# Altium schematic sheet PRi-USB-ETH-CON.SchDoc(PRi-USB-ETH-CON)
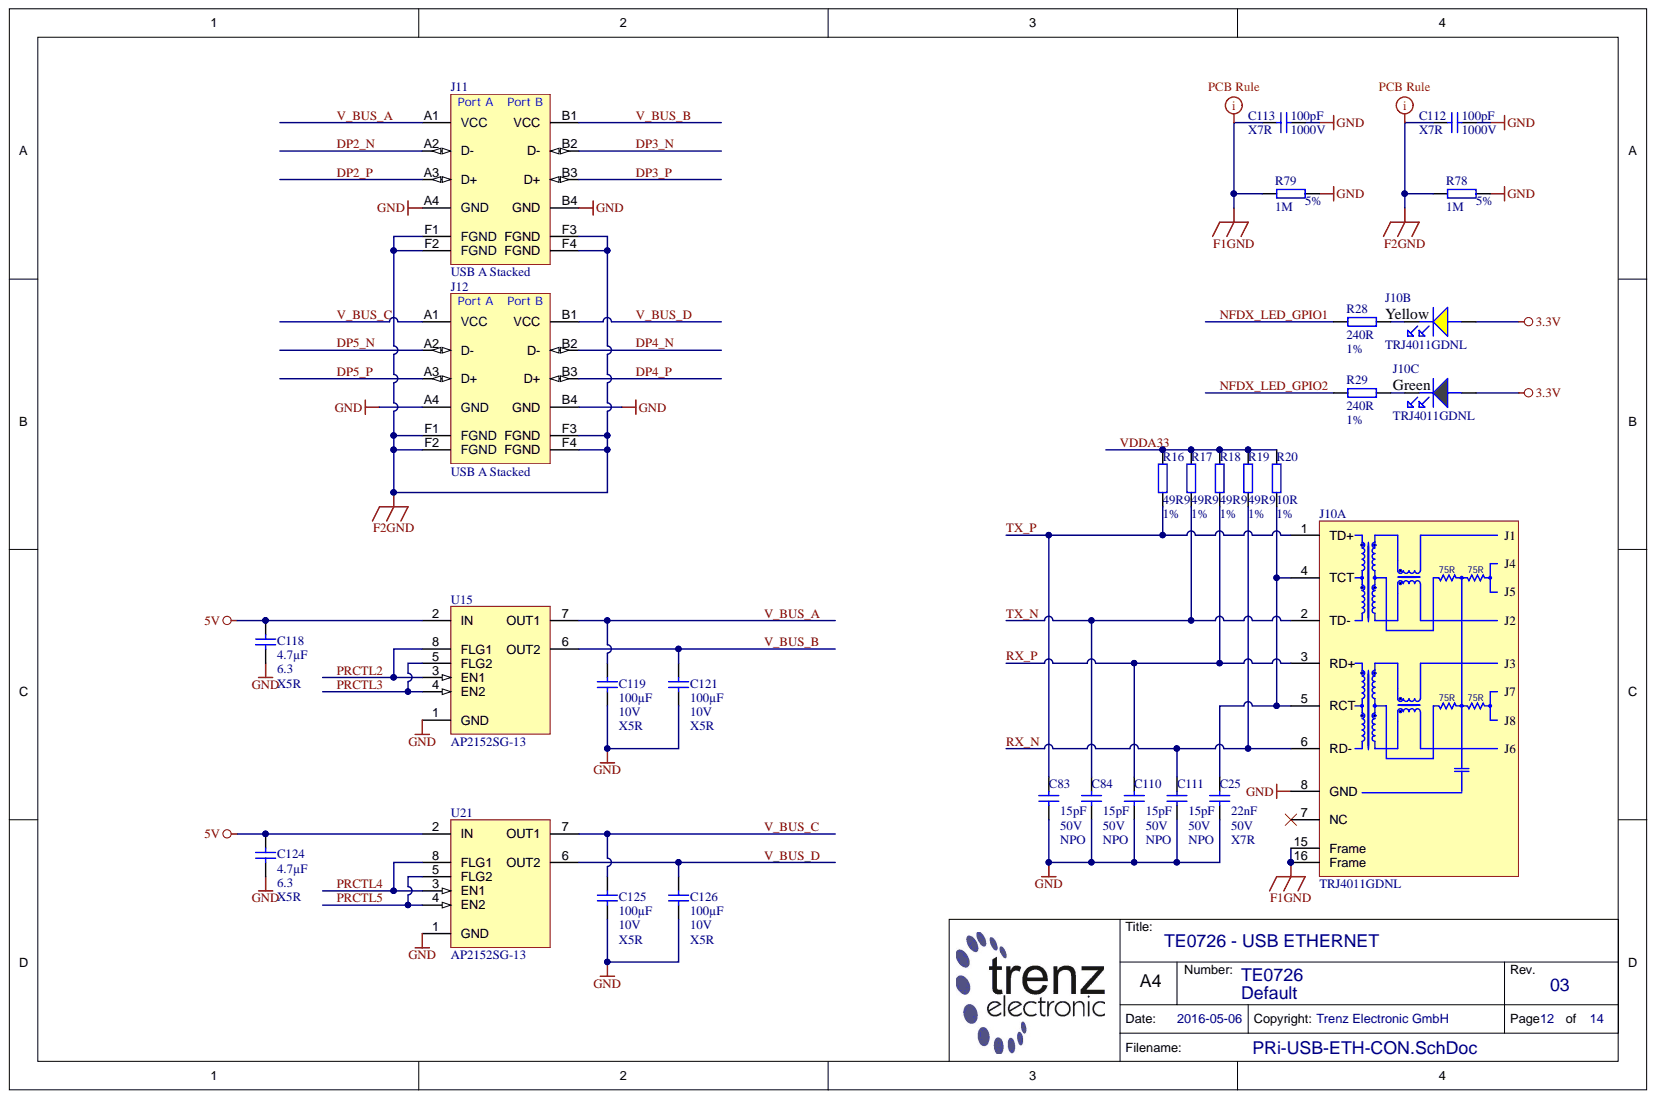
[diagram p0: PRi-USB-ETH-CON.SchDoc]

## Parts
C25  22nF  Technology: X7R  Voltage: 50V  [CAPC1005X55N]
C83  15pF  Technology: NPO  Voltage: 50V  [CAPC1005X55N]
C84  15pF  Technology: NPO  Voltage: 50V  [CAPC1005X55N]
C110  15pF  Technology: NPO  Voltage: 50V  [CAPC1005X55N]
C111  15pF  Technology: NPO  Voltage: 50V  [CAPC1005X55N]
C112  100pF  Technology: 1000V  Voltage: X7R  [CAPC3216X180N]
C113  100pF  Technology: 1000V  Voltage: X7R  [CAPC3216X180N]
C118  4.7µF  Technology: X5R  Voltage: 6.3  [CAPC1005X60N]
C119  100µF  Technology: X5R  Voltage: 10V  [CAPC3216X180N]
C121  100µF  Technology: X5R  Voltage: 10V  [CAPC3216X180N]
C124  4.7µF  Technology: X5R  Voltage: 6.3  [CAPC1005X60N]
C125  100µF  Technology: X5R  Voltage: 10V  [CAPC3216X180N]
C126  100µF  Technology: X5R  Voltage: 10V  [CAPC3216X180N]
J10  TRJ4011GDNL  [7499011222A]  (parts A,B,C)
J11  USB A Stacked  [USB A Stacked]
J12  USB A Stacked  [USB A Stacked]
R16  49R9  Tolerance: 1%  [RESC1005X40N]
R17  49R9  Tolerance: 1%  [RESC1005X40N]
R18  49R9  Tolerance: 1%  [RESC1005X40N]
R19  49R9  Tolerance: 1%  [RESC1005X40N]
R20  10R  Tolerance: 1%  [RESC1005X40N]
R28  240R  Tolerance: 1%  [RESC1005X40N]
R29  240R  Tolerance: 1%  [RESC1005X40N]
R78  1M  Tolerance: 5%  [RESC3216X65N]
R79  1M  Tolerance: 5%  [RESC3216X65N]
U15  AP2152SG-13  [SOIC127P600X175-8N]
U21  AP2152SG-13  [SOIC127P600X175-8N]

## Nets
3.3V: J10.9 J10.11
5V: C118.1 C124.1 U15.2(IN) U21.2(IN)
F1GND: C113.1 J10.15(Frame) J10.16(Frame) R79.1
F2GND: C112.1 J11.F1(FGND) J11.F2(FGND) J11.F3(FGND) J11.F4(FGND) J12.F1(FGND) J12.F2(FGND) J12.F3(FGND) J12.F4(FGND) R78.1
GND: C25.2 C83.2 C84.2 C110.2 C111.2 C112.2 C113.2 C118.2 C119.2 C121.2 C124.2 C125.2 C126.2 J10.8(GND) J11.A4(GND) J11.B4(GND) J12.A4(GND) J12.B4(GND) R78.2 R79.2 U15.1(GND) U21.1(GND)
DP2_N: J11.A2(D-)
DP2_P: J11.A3(D+)
DP3_N: J11.B2(D-)
DP3_P: J11.B3(D+)
DP4_N: J12.B2(D-)
DP4_P: J12.B3(D+)
DP5_N: J12.A2(D-)
DP5_P: J12.A3(D+)
NFDX_LED_GPIO1: R28.1
NFDX_LED_GPIO2: R29.1
PRCTL2: U15.3(EN1) U15.8(FLG1)
PRCTL3: U15.4(EN2) U15.5(FLG2)
PRCTL4: U21.3(EN1) U21.8(FLG1)
PRCTL5: U21.4(EN2) U21.5(FLG2)
RX_N: C111.1 J10.6(RD-) R19.2
RX_P: C110.1 J10.3(RD+) R18.2
TX_N: C84.1 J10.2(TD-) R17.2
TX_P: C83.1 J10.1(TD+) R16.2
V_BUS_A: C119.1 J11.A1(VCC) U15.7(OUT1)
V_BUS_B: C121.1 J11.B1(VCC) U15.6(OUT2)
V_BUS_C: C125.1 J12.A1(VCC) U21.7(OUT1)
V_BUS_D: C126.1 J12.B1(VCC) U21.6(OUT2)
VDDA33: R16.1 R17.1 R18.1 R19.1 R20.1
NetC25_1: C25.1 J10.4(TCT) J10.5(RCT) R20.2
NetJ10_10: J10.10 R28.2
NetJ10_12: J10.12 R29.2

Not connected: J10 7(NC)

## Library data of the parts
C25 = 23470: KON 22nF 50V X7R SMD; Database Table Name: C_1005_0402; Mixed: SMT; Preferred: Yes; Value: 22n; AN: 23470; Case: 1005 (0402); Component Kind: Standard; Library Name: TE.DbLib
C83 C84 C110 C111 = 23951: KON 15pF NPO 50V SMD; Database Table Name: C_1005_0402; Mixed: SMT; Preferred: Yes; Value: 15p; AN: 23951; Case: 1005 (0402); Component Kind: Standard; Library Name: TE.DbLib
C112 C113 = 22679: KON 100pF 1000V X7R 10%; Database Table Name: C_3216_1206; Mixed: SMT; Preferred: Yes; Value: 100p; AN: 22679; Case: 3216 (1206); Component Kind: Standard; Library Name: TE.DbLib; Tolerance: 10%
C118 C124 = 24719: KON 4.7µF 6.3V X5R SMD 20%; Database Table Name: C_1005_0402; Mixed: SMT; Preferred: Yes; Value: 4.7u; AN: 24719; Case: 1005 (0402); Component Kind: Standard; Library Name: TE.DbLib; Tolerance: 20%
C119 C121 C125 C126 = 26278: KON 100µF 10V X5R SMD 20%; Database Table Name: C_3216_1206; Mixed: SMT; Preferred: Yes; Value: 100u; AN: 26278; Case: 3216 (1206); Component Kind: Standard; Library Name: TE.DbLib; Tolerance: 20%
J10 = 27356: LAN-Transformer 10/100 BaseT; Database Table Name: CON_IO; Mixed: THT; Trenz-Stock: Yes; AN: 27356; Component Kind: Standard; Library Name: TE.DbLib; Technology: -40 to +85 °C; HelpURL: B:\ad\adlib\datasheet\TRJ4011GDNL
J11 J12 = 25217: USB A Dual Stacked 2x4; Database Table Name: CON_IO; H-ART: 61400826021; Mixed: THT; Trenz-Stock: Yes; AN: 25217; Component Kind: Standard; Library Name: TE.DbLib; HelpURL: B:\AD\adlib\datasheet\USB\USB A Stacked
R16-R19 = 23135: WID SMD 49R9 1% 0,063W; Database Table Name: R_1005_0402; Mixed: SMT; Preferred: Yes; Value: 49.9; AN: 23135; Case: 1005 (0402); Component Kind: Standard; Library Name: TE.DbLib; Technology: TK100
R20 = 22844: WID SMD 10R 1% 0,063W; Database Table Name: R_1005_0402; Mixed: SMT; Preferred: Yes; Value: 10; AN: 22844; Case: 1005 (0402); Component Kind: Standard; Library Name: TE.DbLib; Technology: TK100
R28 R29 = 23661: WID SMD 240R 1% 0,063W; Database Table Name: R_1005_0402; Mixed: SMT; Preferred: Yes; Value: 240; AN: 23661; Case: 1005 (0402); Component Kind: Standard; Library Name: TE.DbLib; Technology: TK100
R78 R79 = 22757: WID SMD 1M 5% 0,25W; Database Table Name: R_3216_1206; Mixed: SMT; Preferred: Yes; AN: 22757; Case: 3216 (1206); Component Kind: Standard; Library Name: TE.DbLib; Technology: TK100; Value: 1M
U15 U21 = 26982: Database Table Name: SWITCH; Mixed: SMT; AN: 26982; Case: SO8; Component Kind: Standard; Description: AP2152SG-13; H-ART: AP2152SG-13; Library Name: TE.DbLib; HelpURL: B:\ad\adlib\datasheet\AP2152
